# Altium schematic sheet Endpoint++.SchDoc
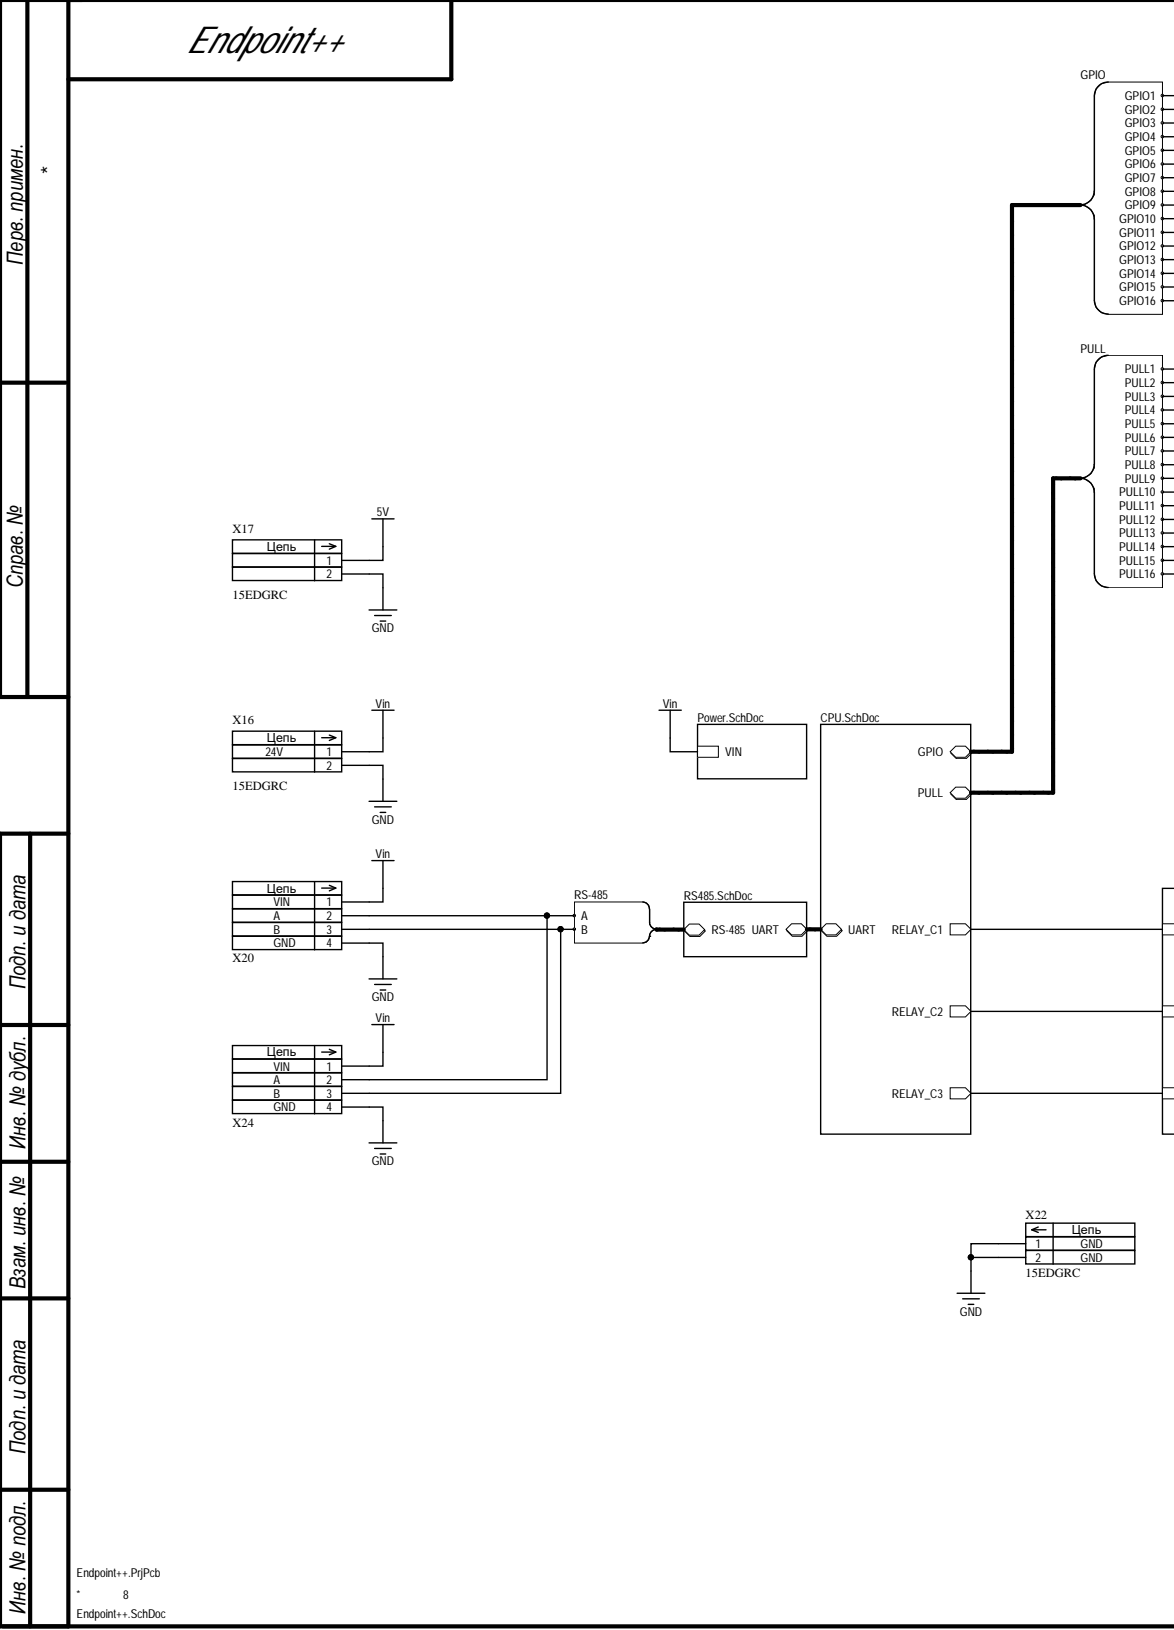
[diagram p0: Endpoint++.SchDoc, left]
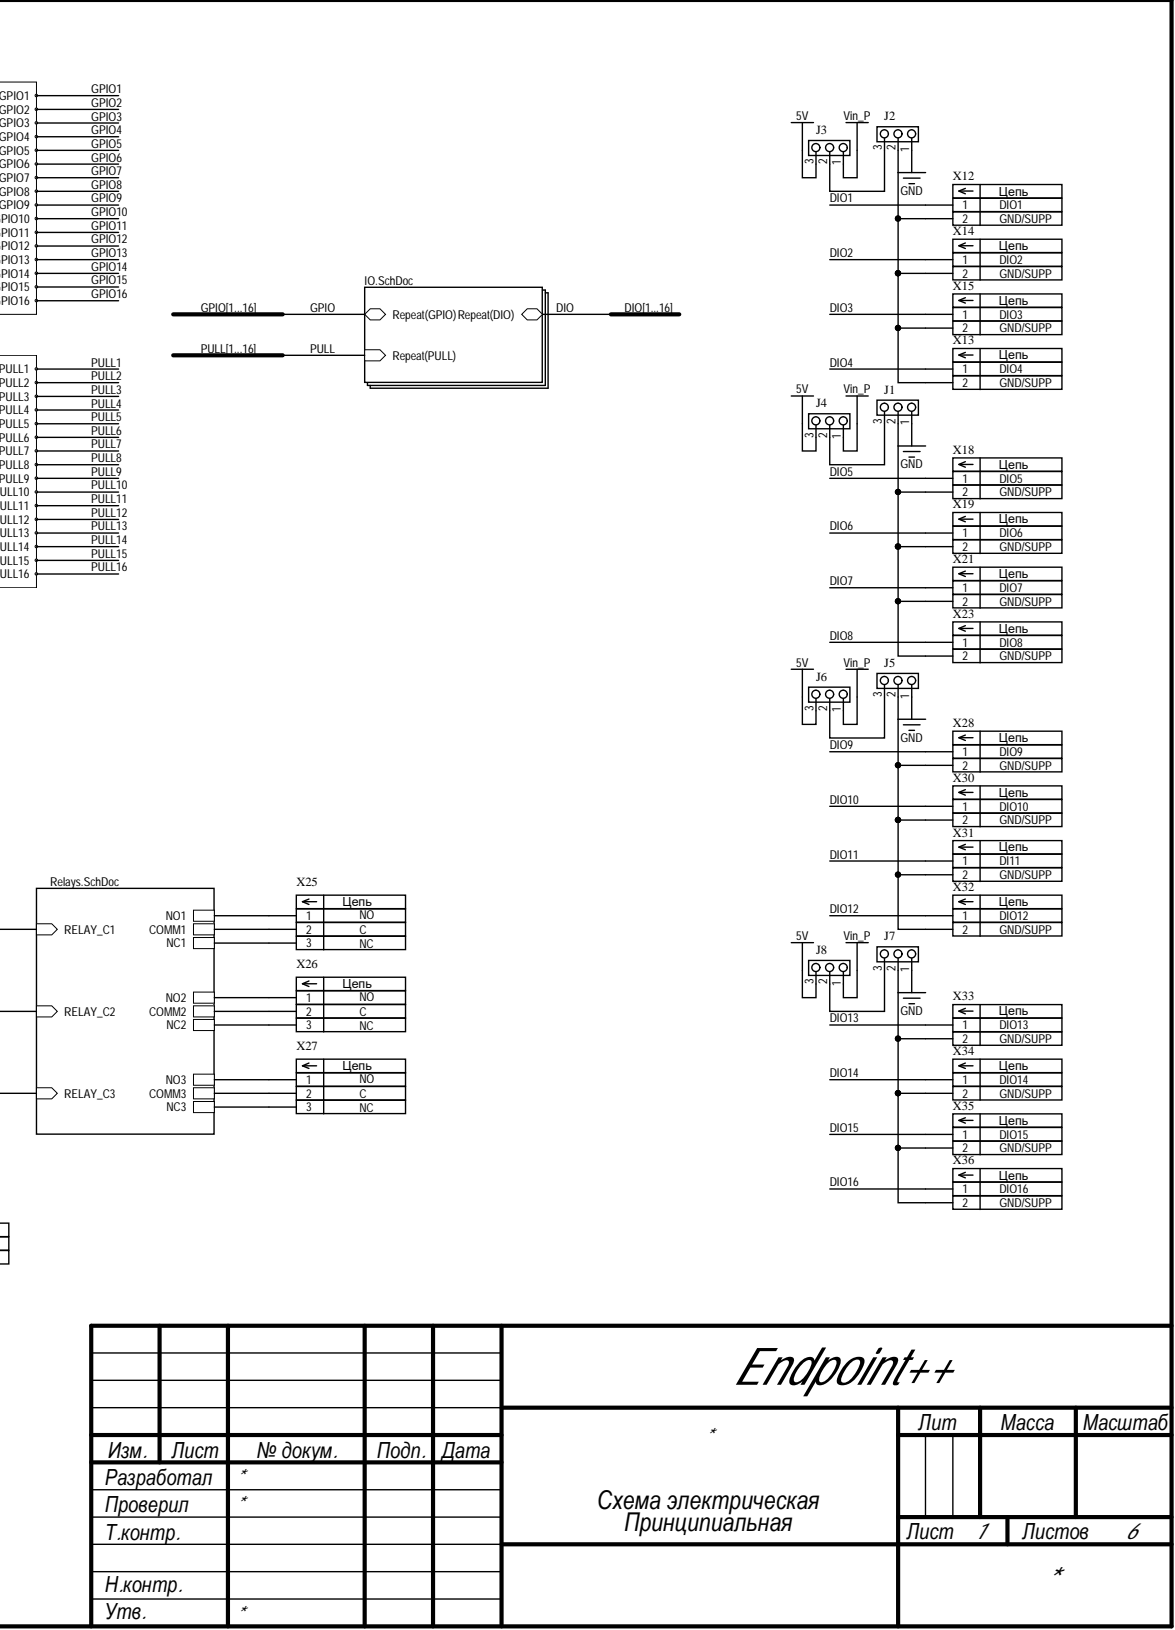
[diagram p1: Endpoint++.SchDoc, right]

## Parts
J1  Jumper 2pos  [JUMPER 2pos]  (value not drawn)
J2  Jumper 2pos  [JUMPER 2pos]  (value not drawn)
J3  Jumper 2pos  [JUMPER 2pos]  (value not drawn)
J4  Jumper 2pos  [JUMPER 2pos]  (value not drawn)
J5  Jumper 2pos  [JUMPER 2pos]  (value not drawn)
J6  Jumper 2pos  [JUMPER 2pos]  (value not drawn)
J7  Jumper 2pos  [JUMPER 2pos]  (value not drawn)
J8  Jumper 2pos  [JUMPER 2pos]  (value not drawn)
X12  15EDGRC  [15EDGRC-3.5-02]  (value not drawn)
X13  15EDGRC  [15EDGRC-3.5-02]  (value not drawn)
X14  15EDGRC  [15EDGRC-3.5-02]  (value not drawn)
X15  15EDGRC  [15EDGRC-3.5-02]  (value not drawn)
X16  15EDGRC  [15EDGRC-3.5-02]
X17  15EDGRC  [15EDGRC-3.5-02]
X18  15EDGRC  [15EDGRC-3.5-02]  (value not drawn)
X19  15EDGRC  [15EDGRC-3.5-02]  (value not drawn)
X20  15EDGRC  [15EDGRC-3.5-04]  (value not drawn)
X21  15EDGRC  [15EDGRC-3.5-02]  (value not drawn)
X22  15EDGRC  [15EDGRC-3.5-02]  (no BOM)
X23  15EDGRC  [15EDGRC-3.5-02]  (value not drawn)
X24  15EDGRC  [15EDGRC-3.5-04]  (value not drawn)
X25  15EDGRC  [15EDGRC-3.5-03]  (value not drawn)
X26  15EDGRC  [15EDGRC-3.5-03]  (value not drawn)
X27  15EDGRC  [15EDGRC-3.5-03]  (value not drawn)
X28  15EDGRC  [15EDGRC-3.5-02]  (value not drawn)
X30  15EDGRC  [15EDGRC-3.5-02]  (value not drawn)
X31  15EDGRC  [15EDGRC-3.5-02]  (value not drawn)
X32  15EDGRC  [15EDGRC-3.5-02]  (value not drawn)
X33  15EDGRC  [15EDGRC-3.5-02]  (value not drawn)
X34  15EDGRC  [15EDGRC-3.5-02]  (value not drawn)
X35  15EDGRC  [15EDGRC-3.5-02]  (value not drawn)
X36  15EDGRC  [15EDGRC-3.5-02]  (value not drawn)

## Nets
5V: J3.3 J4.3 J6.3 J8.3 X17.1
GND: J1.1 J2.1 J5.1 J7.1 X16.2 X17.2 X20.4 X22.1 X22.2 X24.4
Vin: X16.1 X20.1 X24.1
Vin_P: J3.1 J4.1 J6.1 J8.1
DIO1: X12.1
DIO2: X14.1
DIO3: X15.1
DIO4: X13.1
DIO5: X18.1
DIO6: X19.1
DIO7: X21.1
DIO8: X23.1
DIO9: X28.1
DIO10: X30.1
DIO11: X31.1
DIO12: X32.1
DIO13: X33.1
DIO14: X34.1
DIO15: X35.1
DIO16: X36.1
NetJ1_2: J1.2 X18.2 X19.2 X21.2 X23.2
NetJ1_3: J1.3 J4.2
NetJ2_2: J2.2 X12.2 X13.2 X14.2 X15.2
NetJ2_3: J2.3 J3.2
NetJ5_2: J5.2 X28.2 X30.2 X31.2 X32.2
NetJ5_3: J5.3 J6.2
NetJ7_2: J7.2 X33.2 X34.2 X35.2 X36.2
NetJ7_3: J7.3 J8.2
NetX20_2: X20.2 X24.2
NetX20_3: X20.3 X24.3
NetX25_1: X25.1
NetX25_2: X25.2
NetX25_3: X25.3
NetX26_1: X26.1
NetX26_2: X26.2
NetX26_3: X26.3
NetX27_1: X27.1
NetX27_2: X27.2
NetX27_3: X27.3
DIO[1...16]:
GPIO1:
GPIO2:
GPIO3:
GPIO4:
GPIO5:
GPIO6:
GPIO7:
GPIO8:
GPIO9:
GPIO10:
GPIO11:
GPIO12:
GPIO13:
GPIO14:
GPIO15:
GPIO16:
GPIO[1...16]:
PULL1:
PULL2:
PULL3:
PULL4:
PULL5:
PULL6:
PULL7:
PULL8:
PULL9:
PULL10:
PULL11:
PULL12:
PULL13:
PULL14:
PULL15:
PULL16:
PULL[1...16]:
RELAY_C1:
RELAY_C2:
RELAY_C3:
UART.DE:
UART.RX:
UART.TX:

## Library data of the parts
J1-J8 = Jumper 2pos: Джампер штыревой на плату 2.54мм; Database Table Name: Connector; Component Kind: Standard; ComponentLink1Description: Manufacturer Link; Library Name: Library.DbLib; Package: PLS-2; Part Number: Jumper 2pos
X12-X19 X21-X23 X28 X30-X36 = 15EDGRC-3.5-02: Разъемный клеммный блок на плату; Database Table Name: Connector; ComponentLink1Description: Manufacturer Link; ComponentLink2Description: Datasheet; Library Name: Library.DbLib; net1: net1; net2: net2; Part Number: 15EDGRC-3.5-02
  X12-X19 X21 X23 X28 X30-X36: Component Kind: Standard
X20 X24 = 15EDGRC-3.5-04: Разъемный клеммный блок на плату; Database Table Name: Connector; Component Kind: Standard; ComponentLink1Description: Manufacturer Link; ComponentLink2Description: Datasheet; Library Name: Library.DbLib; Part Number: 15EDGRC-3.5-04
X25-X27 = 15EDGRC-3.5-03: Разъемный клеммный блок на плату; Database Table Name: Connector; Component Kind: Standard; ComponentLink1Description: Manufacturer Link; ComponentLink2Description: Datasheet; Library Name: Library.DbLib; Part Number: 15EDGRC-3.5-03
